# Altium schematic sheet 04-PS4.SchDoc
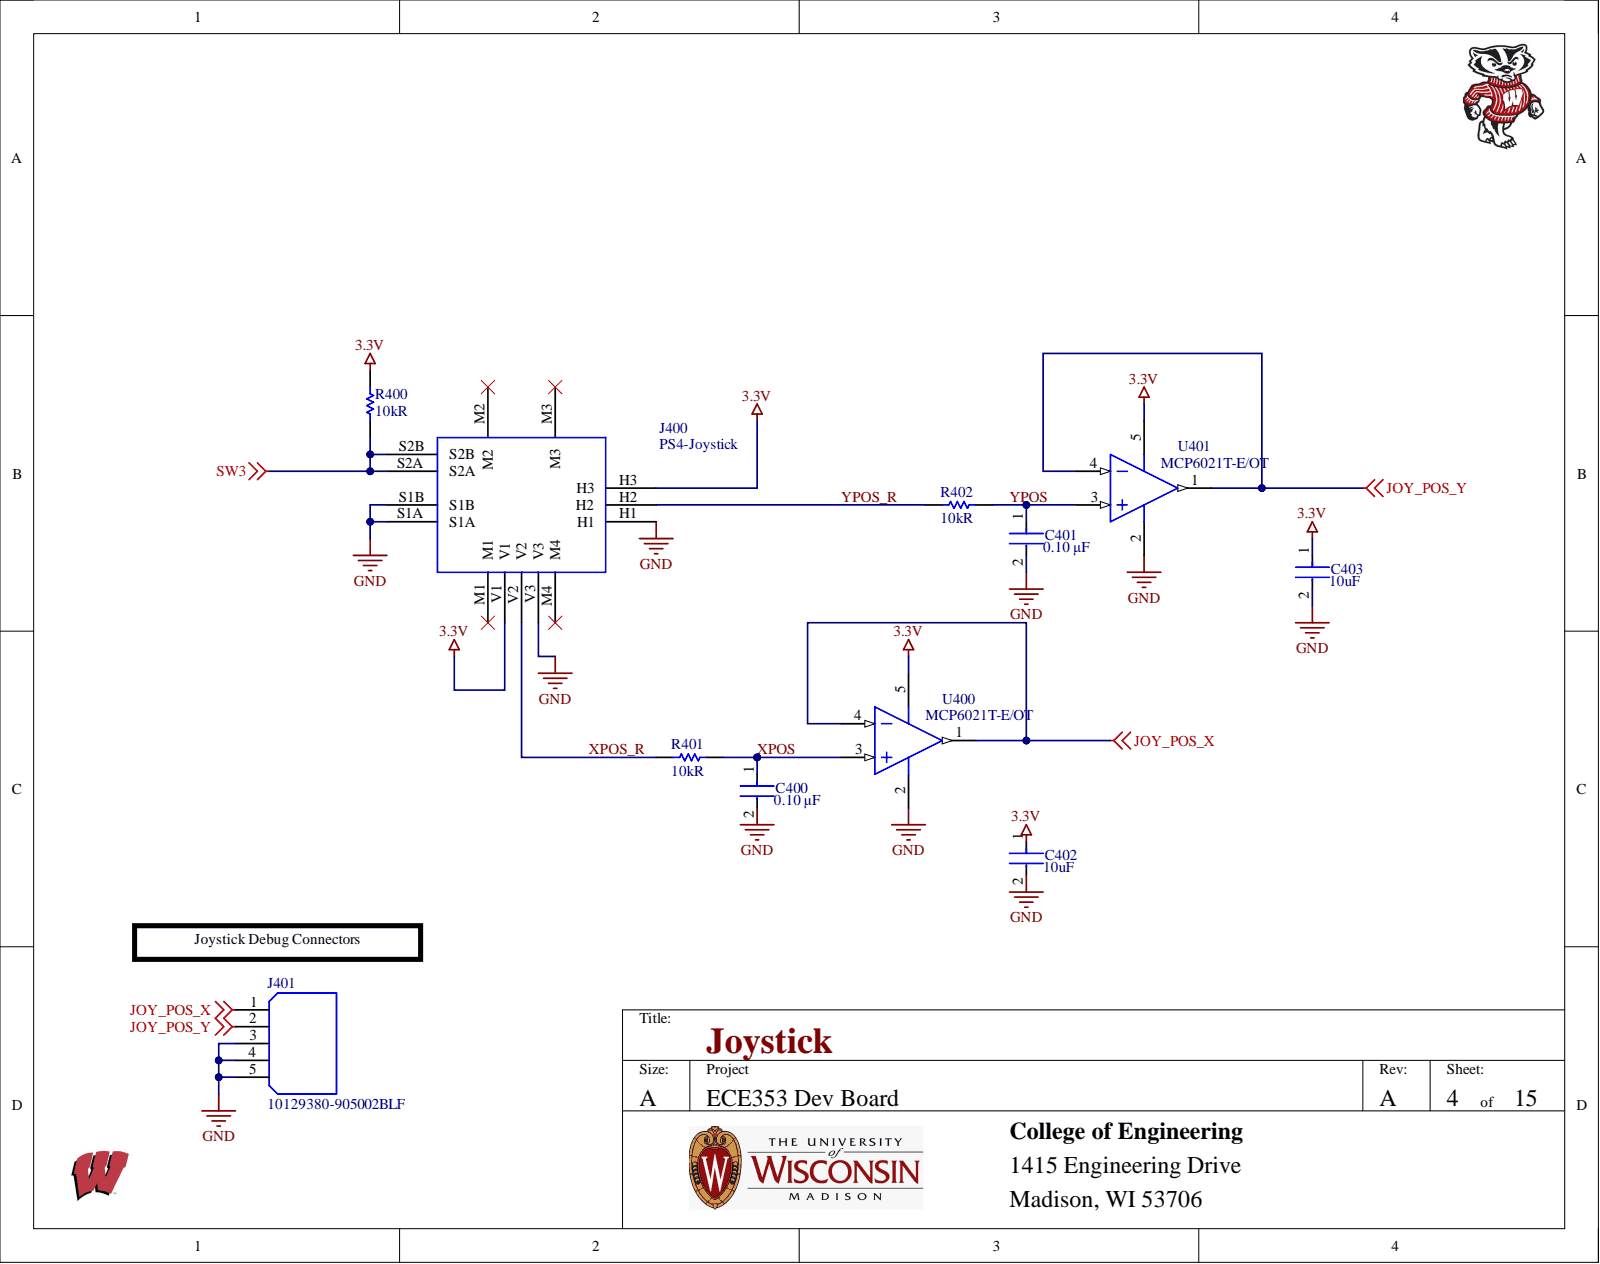
[diagram p0: 04-PS4.SchDoc]

## Parts
C400  value 0.10 μF  [FP-C0805C-DN-MFG]  (hidden comment: C0805C104K5RACTU)
C401  value 0.10 μF  [FP-C0805C-DN-MFG]  (hidden comment: C0805C104K5RACTU)
C402  value 10uF  Capacitance: 10uF  [FP-C0805C-DG-MFG]  (hidden comment: C0805C106K8PACTU)
C403  value 10uF  Capacitance: 10uF  [FP-C0805C-DG-MFG]  (hidden comment: C0805C106K8PACTU)
J400  PS4-Joystick  [PS4-Joystick]
J401  10129380-905002BLF  [AMPHENOL_10129380-905002BLF]
R400  Resistance: 10kR  [RESC2013X60X35NL10T20]  (hidden comment: RC0805FR-0710KL)
R401  Resistance: 10kR  [RESC2013X60X35NL10T20]  (hidden comment: RC0805FR-0710KL)
R402  Resistance: 10kR  [RESC2013X60X35NL10T20]  (hidden comment: RC0805FR-0710KL)
U400  MCP6021T-E/OT  [SOT-23-OT5_N]
U401  MCP6021T-E/OT  [SOT-23-OT5_N]

## Nets
3.3V: C402.1 C403.1 J400.H3 J400.V1 R400.1 U400.5 U401.5
GND: C400.2 C401.2 C402.2 C403.2 J400.H1 J400.S1A J400.S1B J400.V3 J401.3 J401.4 J401.5 U400.2 U401.2
JOY_POS_X: J401.1 U400.1 U400.4
JOY_POS_Y: J401.2 U401.1 U401.4
SW3: J400.S2A J400.S2B R400.2
XPOS: C400.1 R401.1 U400.3
XPOS_R: J400.V2 R401.2
YPOS: C401.1 R402.1 U401.3
YPOS_R: J400.H2 R402.2

Not connected: J400 M1 M2 M3 M4

## Library data of the parts
C400 C401 = CMP-2007-00279-2: CAP CER 0.1UF 50V X7R 0805; Manufacturer: KEMET; Dielectric Material: Ceramic; Temperature Coefficient: 30ppm/°C; Tolerance: 0.1; Voltage: 500V; Automotive: No; Capacitance: 0.10 μF; Case Code (Imperial): 0805; Case Code (Metric): 2012; Case/Package: 0805; Category: Cap; Component Kind: Standard; ComponentLink2Description: Datasheet; ComponentLink2URL: https://content.kemet.com/datasheets/KEM_C1003_C0G_SMD.pdf; Construction: Flat; Contact Plating: Tin,Matte; Depth: 1.25mm; Device Class L1: Passive Components; Device Class L2: Capacitors; Device Class L3: Ceramic Capacitors; Dielectric: X7R; Digikey Description: CAP CER 0.1UF 50V X7R 0805; Height: 0.88mm; Ipc Land Pattern Name: CAPC200125X78; Lead Free: Yes; Lead Pitch: 0.75mm; Length: 2mm; Library Name: Altium Content Vault; Manufacturer 1: KEMET; Manufacturer Part Number: C0805C104K5RACTU; Manufacturer Part Number 1: C0805C104K5RACTU; Material: Ceramic; Max Operating Temperature: 125°C; Min Operating Temperature: -55°C; Mount: Surface Mount,PCB; Mouser Description: Cap Ceramic 0.1uF 50V X7R 10% SMD 2.0 x 1.2 mm 125 C Paper T/R; Number of Pins: 2; Octopart: https://octopart.com/c0805c104k5ractu-kemet-143926; Package: C0805C-DN; Package Quantity: 4000; Packaging: Tape and Reel; Pricing 1: 1=0.14000, 10=0.09700, 50=0.05480, 100=0.04540, 500=0.03182, 1000=0.02634 (USD); Radiation Hardening: No; Reach SVHC Compliant: Yes; RoHS: TRUE; RoHS Compliant: Yes; Series: SMD Comm X7R; Stock 1: 2163733; Supplier 1: Digi-Key; Supplier Part Number 1: 399-C0805C104K5RAC7800CT-ND; Temperature: -55°C to +125°C; Temperature Characteristic: X7R; Temperature Range High: +125°C; Temperature Range Low: -55°C; Termination: SMD/SMT; Thickness: 0.035inch; Voltage Rating: 50 V; Voltage Rating (DC): 50V; Width: 1.2mm
C402 C403 = CMP-2007-03243-2: CAP CER 10UF 10V X5R 0805; 3DS Part Number: 220-00001; Manufacturer: Kemet; Dielectric Material: Ceramic; Dissipation Factor: 10%; Temperature Coefficient: 15%; Automotive: No; Case Code (Imperial): 0805; Case Code (Metric): 2012; Case/Package: 0805; Category: Cap; Component Kind: Standard; ComponentLink2Description: Datasheet; ComponentLink2URL: https://content.kemet.com/datasheets/KEM_C1006_X5R_SMD.pdf; Construction: Flat; Contact Plating: Tin,Matte; Depth: 1.25mm; Device Class L1: Passive Components; Device Class L2: Capacitors; Device Class L3: Ceramic Capacitors; Dielectric: X5R; Digikey Description: CAP CER 10UF 10V X5R 0805; Height: 1.4mm; Ipc Land Pattern Name: CAPC200125X125; Lead Free: Yes; Length: 2mm; Library Name: Altium Content Vault; Manufacturer 1: KEMET; Manufacturer Part Number: C0805C106K8PACTU; Manufacturer Part Number 1: C0805C106K8PACTU; Material: Ceramic; Max Operating Temperature: 85°C; Min Operating Temperature: -55°C; Mount: Surface Mount; Mouser Description: CAP CER 10UF 10V X5R 0805; Number of Pins: 2; Octopart: https://octopart.com/c0805c106k8pactu-kemet-98697; Package: C0805C-DG; Package Quantity: 1; Packaging: Tape and Reel; Pricing 1: 1=0.14000, 10=0.10300, 50=0.05840, 100=0.04830, 500=0.03388, 1000=0.02805 (USD); Radiation Hardening: No; Reach SVHC Compliant: Yes; RoHS: TRUE; RoHS Compliant: Yes; Series: C; Stock 1: 8381466; Supplier 1: Digi-Key; Supplier Part Number 1: 399-C0805C106K8PAC7800CT-ND; Temperature: -55°C to +85°C; Temperature Characteristic: X5R; Temperature Range High: +85°C; Temperature Range Low: -55°C; Termination: SMD/SMT; Thickness: 0.055inch; Tolerance: 10%; Voltage: 10V; Voltage Rating: 10V; Voltage Rating (DC): 10V; Width: 0.049inch
J401 = 10129380-905002BLF: Conn Unshrouded Header HDR 5 POS 2.54mm Solder ST SMD Poly Bag; Manufacturer 1: ICC; MF: AMPHENOL ICC / FCI; Availability: In Stock; Check_prices: https://www.snapeda.com/parts/10129380-905002BLF/Amphenol/view-part/?ref=eda; Component Kind: Standard; Library Name: ece353-2022.SchLib; Manufacturer Part Number 1: 10129380-905002BLF; MP: 10129380-905002BLF; Package: None; Price: None; Purchase-URL: https://pricing.snapeda.com/search/part/10129380-905002BLF/?ref=eda; RoHS: No; SnapEDA_Link: https://www.snapeda.com/parts/10129380-905002BLF/Amphenol/view-part/?ref=snap; Supplier 1: Digi-Key; Supplier Part Number 1: 10129380-905002BLF-ND
R400-R402 = CMP-1659-00013-4: Chip Resistor, 10 KOhm, +/- 1%, 0.125 W, -55 to 155 degC, 0805 (2012 Metric), RoHS, Tape and Reel; Manufacturer: Yageo; Max Power Dissipation: 125mW; Temperature Coefficient: 100ppm/°C; Voltage Rating: 150 V; Case Code (Imperial): 0805; Case Code (Metric): 2012; Case/Package: 0805; Category: Chip SMD Resistors; Component Kind: Standard; ComponentLink1Description: Manufacturer URL; ComponentLink1URL: http://www.yageo.com/; ComponentLink2Description: Datasheet; ComponentLink2URL: http://www.yageo.com/documents/recent/PYu-RC_Group_51_RoHS_L_04.pdf; Construction: Rectangular; DatasheetVersion: Rev. 4, 02/2016; Depth: 1.25mm; Features: Moisture Resistant; Height: 0.5 mm; Lead Free: Lead Free; Length: 2 mm; Library Name: Altium Content Vault; Manufacturer 1: Yageo; Manufacturer Part Number 1: RC0805FR-0710KL; Max Operating Temperature: 155 degC; Military Standard: Not; Min Operating Temperature: -55 degC; Mount: Surface Mount; Mounting Technology: Surface Mount; Number of Terminations: 2; Package Quantity: 5000; PackageDescription: 2-Pin Surface Mounted Device, Body 2 x 1.25 mm; PackageReference: 0805; Packaging: Tape and Reel; Pins: 2; Power Rating: 125mW; Pricing 1: 1=0.10000, 10=0.03700, 100=0.01520, 1000=0.00682, 2500=0.00592 (USD); REACH SVHC: No SVHC; Resistance Tolerance: 1%; Resistor Type: Thick Film; RoHS: Yes; RoHS Compliant: Yes; RoHSCompliant: true; Series: RC; Size Code: 0805; Stock 1: 12202331; Supplier 1: Digi-Key; Supplier Part Number 1: 311-10.0KCRCT-ND; Technology: THICK FILM; Termination: SMD/SMT; Tolerance: 1%; Width: 0.049inch
U400 U401 = CMP-0187-00449-3: None; Manufacturer: Microchip; Amplifier Type: GENERAL PURPOSE; Bandwidth: 10MHz; Clock Frequency: 10kHz; Common Mode Rejection Ratio: 70dB; Input Bias Current: 1pA; Input Offset Voltage (Vos): 250uV; Input Offset Voltage-Max: 500uV; Max Input Voltage: 5.5V; Min Input Voltage: 2.5V; Nominal Supply Current: 1mA; Number of Amplifiers: 1; Output Current: 22mA; Output Current per Channel: 30mA; Power Supply Rejection Ratio (PSRR): 74dB; Slew Rate: 7V/µs; Voltage Gain: 110dB; Case/Package: SOT-23; Category: Amplifiers - Op Amps, Buffer, Instrumentation; Component Kind: Standard; ComponentLink1Description: https://datasheet.ciiva.com/1793/21685d-1793712.pdf?src-supplier=Digi-Key; ComponentLink1URL: https://datasheet.ciiva.com/1793/21685d-1793712.pdf?src-supplier=Digi-Key; ComponentLink2Description: https://datasheet.ciiva.com/26344/651497-da-01-en-ic-opamp-mcp6021t-e-ot-sot-23-5-mcp-26344507.pdf?src-supplier=Conrad; ComponentLink2URL: https://datasheet.ciiva.com/26344/651497-da-01-en-ic-opamp-mcp6021t-e-ot-sot-23-5-mcp-26344507.pdf?src-supplier=Conrad; ComponentLink3Description: https://datasheet.ciiva.com/14151/mcp602x-eng-tds-14151463.pdf?src-supplier=Distrelec; ComponentLink3URL: https://datasheet.ciiva.com/14151/mcp602x-eng-tds-14151463.pdf?src-supplier=Distrelec; ComponentLink4Description: https://datasheet.ciiva.com/46385/630323-46385309.pdf?src-supplier=Element14; ComponentLink4URL: https://datasheet.ciiva.com/46385/630323-46385309.pdf?src-supplier=Element14; ComponentLink5Description: https://datasheet.ciiva.com/11711/21685d-11711036.pdf?src-supplier=Avnet; ComponentLink5URL: https://datasheet.ciiva.com/11711/21685d-11711036.pdf?src-supplier=Avnet; ComponentLink6Description: https://datasheet.ciiva.com/1793/21685d-1793713.pdf?src-supplier=Digi-Key; ComponentLink6URL: https://datasheet.ciiva.com/1793/21685d-1793713.pdf?src-supplier=Digi-Key; ComponentLink7Description: https://datasheet.ciiva.com/1793/21685d-1793714.pdf?src-supplier=Digi-Key; ComponentLink7URL: https://datasheet.ciiva.com/1793/21685d-1793714.pdf?src-supplier=Digi-Key; Contact Plating: Tin; Gain Bandwidth Product: 10MHz; Height: 0.051inch; Lead Free: Lead Free; Length: 0.122inch; Library Name: MCP6021T.SchLib; Manufacturer Part Number: MCP6021T-E/OT; Max Frequency: 10kHz; Max Operating Temperature: 125°C; Max Supply Voltage: 5.5V; Min Operating Temperature: -40°C; Min Supply Voltage: 2.5V; Mount: Surface Mount; Mounting Technology: Surface Mount; Number of Channels: 1; Number of Circuits: 1; Number of Elements: 1; Number of Pins: 5; Operating Supply Current: 1mA; Package Quantity: 3000; Packaging: Tape and Reel; Pins: 5; Pricing: 1=1.35, 25=1.13, 100=1.02 (USD); Radiation Hardening: No; REACH SVHC: No SVHC; Reach SVHC Compliant: No; RoHS: Yes; RoHS Compliant: Yes; Stock: 54563; Supplier: Digi-Key; Supplier Part Number: MCP6021T-E/OTCT-ND; Supply Current: 1mA; Technology: CMOS; Unity Gain Bandwidth Product: 10MHz; Width: 0.071inch
J400 = PS4-Joystick: Component Kind: Standard; Library Name: ece353-2022.SchLib
